# Altium schematic sheet WalkMod B0.SchDoc
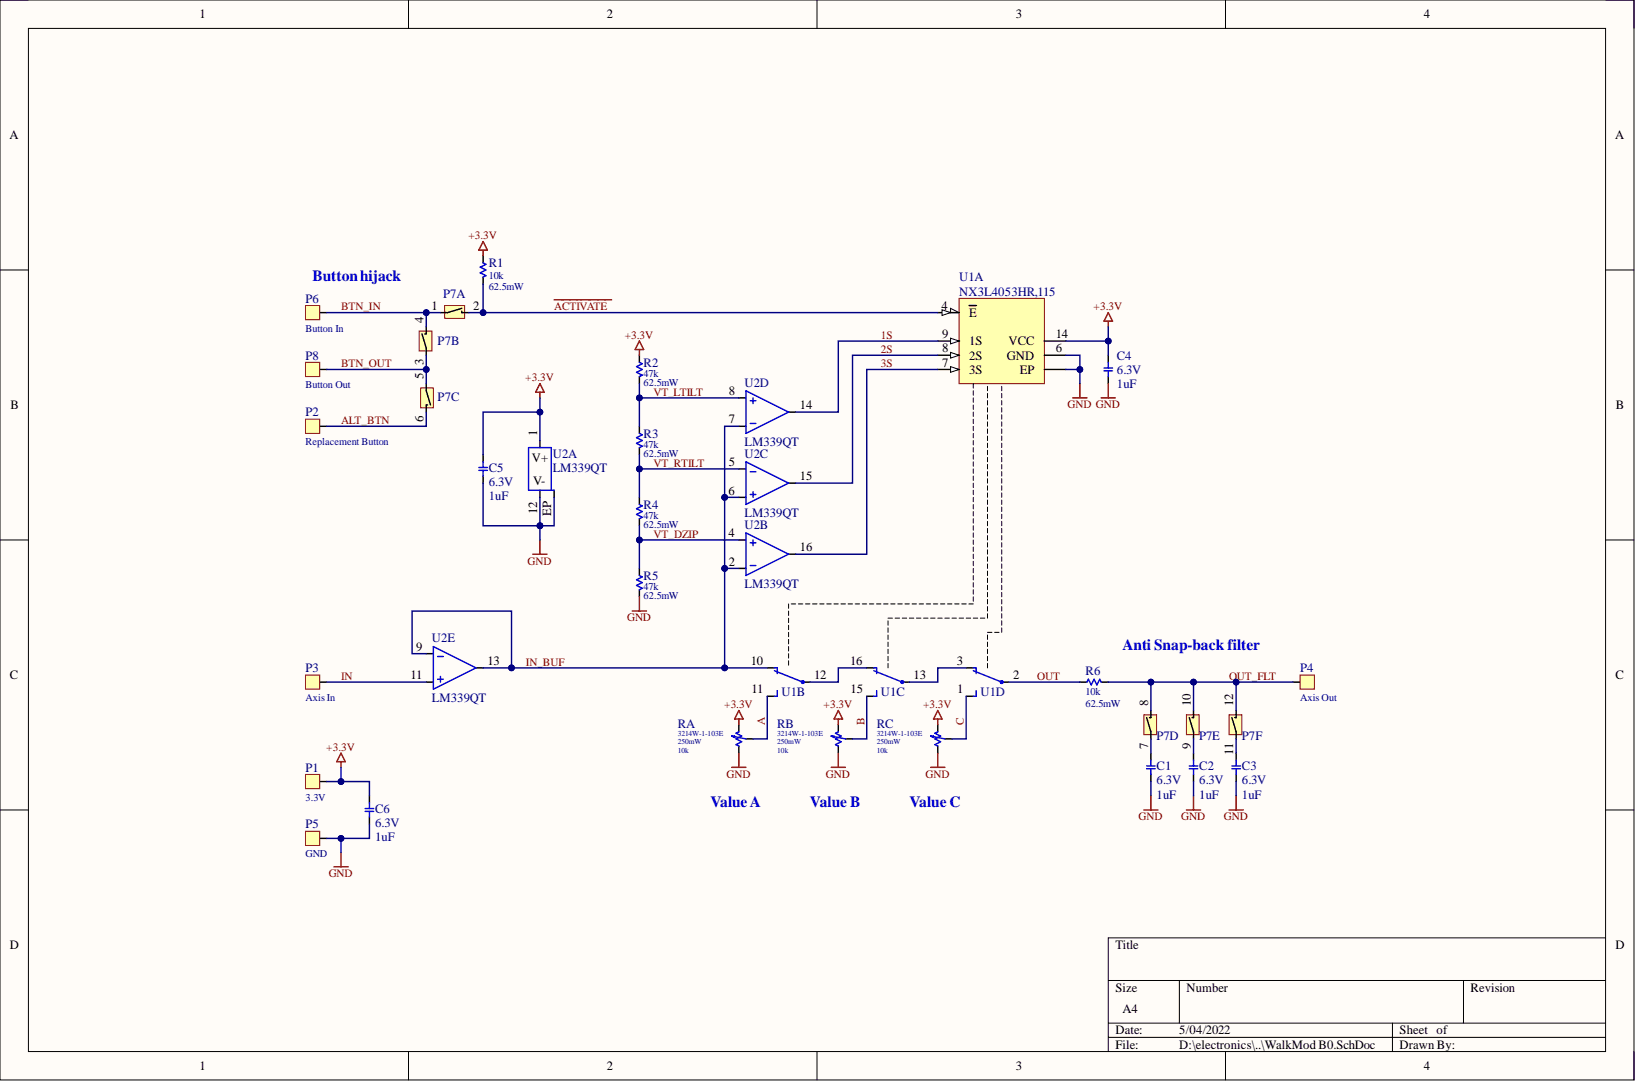
[diagram p0: WalkMod B0.SchDoc]

## Parts
C1  value 1uF  Value2: 6.3V  [CX7R0603K]  (hidden comment: 06036C105JAT2A)
C2  value 1uF  Value2: 6.3V  [CX7R0603K]  (hidden comment: 06036C105JAT2A)
C3  value 1uF  Value2: 6.3V  [CX7R0603K]  (hidden comment: 06036C105JAT2A)
C4  value 1uF  Value2: 6.3V  [CX7R0603K]  (hidden comment: 06036C105JAT2A)
C5  value 1uF  Value2: 6.3V  [CX7R0603K]  (hidden comment: 06036C105JAT2A)
C6  value 1uF  Value2: 6.3V  [CX7R0603K]  (hidden comment: 06036C105JAT2A)
P1  Name: 3.3V  [PAD_2X1.5_SQUARE]  (hidden comment: SMD Pad 1 pin)
P2  SMD Pad 1 pin  [PAD_2X1.5_SQUARE]  (value not drawn)
P3  Name: Axis In  [PAD_2X1.5_SQUARE]  (hidden comment: SMD Pad 1 pin)
P4  Name: Axis Out  [PAD_2X1.5_SQUARE]  (hidden comment: SMD Pad 1 pin)
P5  Name: GND  [PAD_2X1.5_SQUARE]  (hidden comment: SMD Pad 1 pin)
P6  Name: Button In  [PAD_2X1.5_SQUARE]  (hidden comment: SMD Pad 1 pin)
P7  DS05-127-2-06BK-SMT-TR  [CUI-DS05-127-2-06BK-SMT_C]  (value not drawn)  (parts A,B,C,D,E,F)
P8  Name: Button Out  [PAD_2X1.5_SQUARE]  (hidden comment: SMD Pad 1 pin)
R1  value 10k  Value2: 62.5mW  [AT0402_C]  (hidden comment: AT0402FRE0710KL)
R2  value 47k  Value2: 62.5mW  [RT0402_C]  (hidden comment: RT0402DRD0747KL)
R3  value 47k  Value2: 62.5mW  [RT0402_C]  (hidden comment: RT0402DRD0747KL)
R4  value 47k  Value2: 62.5mW  [RT0402_C]  (hidden comment: RT0402DRD0747KL)
R5  value 47k  Value2: 62.5mW  [RT0402_C]  (hidden comment: RT0402DRD0747KL)
R6  value 10k  Value2: 62.5mW  [AT0402_C]  (hidden comment: AT0402FRE0710KL)
RA  3214W-1-103E  value 10k  Value2: 250mW  [BOURNS_3214W_C]
RB  3214W-1-103E  value 10k  Value2: 250mW  [BOURNS_3214W_C]
RC  3214W-1-103E  value 10k  [BOURNS_3214W_C]
U1  NX3L4053HR,115  [HXQFN16_SOT1039-2_C]  (parts A,B,C,D)
U2  LM339QT  [QFN16_0.5_C]  (parts A,B,C,D,E)

## Nets
+3.3V: C4.2 C5.2 C6.2 P1.1 R1.1 R2.2 RA.3 RB.3 RC.3 U1.14(VCC) U2.1(V+)
GND: C1.1 C2.1 C3.1 C4.1 C5.1 C6.1 P5.1 R5.1 RA.1 RB.1 RC.1 U1.6(GND) U1.EP U2.12(V-) U2.EP
1S: U1.9(1S) U2.14
2S: U1.8(2S) U2.15
3S: U1.7(3S) U2.16
A: RA.2 U1.11
~{ACTIVATE}: P7.2 R1.2 U1.4(E)
ALT_BTN: P2.1 P7.6
B: RB.2 U1.15
BTN_IN: P6.1 P7.1 P7.4
BTN_OUT: P7.3 P7.5 P8.1
C: RC.2 U1.1
IN: P3.1 U2.11
IN_BUF: U1.10 U2.2 U2.6 U2.7 U2.9 U2.13
OUT: R6.1 U1.2
OUT_FLT: P4.1 P7.8 P7.10 P7.12 R6.2
VT_DZIP: R4.1 R5.2 U2.4
VT_LTILT: R2.1 R3.2 U2.8
VT_RTILT: R3.1 R4.2 U2.5
NetC1_2: C1.2 P7.7
NetC2_2: C2.2 P7.9
NetC3_2: C3.2 P7.11
NetU1_3: U1.3 U1.13
NetU1_12: U1.12 U1.16

## Library data of the parts
C1-C6 = kyocera-C-X7R-0603: SMD Capacitor; CapacitanceCode: 105; Dielectric: C; MaxThicknessCode: K; ToleranceCode: J; VoltageCode: 6; Component Kind: Standard; Size: 0603; Library Name: WalkMod.IntLib
P1 P3-P6 P8 = SMD Pad 1 pin: Single SMD Pad; Component Kind: Standard; Library Name: WalkMod.IntLib
P2 = SMD Pad 1 pin: Single SMD Pad; Name: Replacement Button; Component Kind: Standard; Library Name: WalkMod.IntLib
P7 = DS05-127-2-06BK-SMT-TR: Dip Switch SPST 6 Position Surface Mount Slide (Standard) Actuator 25mA 24VDC; Component Kind: Standard; Type: DS05-127-2-06BK-SMT-TR; Library Name: WalkMod.IntLib
R1 R6 = AT0402FRE0710KL: 10 kOhms ±1% 0.063W, 1/16W Chip Resistor 0402 (1005 Metric) Anti-Sulfur, Automotive AEC-Q200, Moisture Resistant Thin Film; Component Kind: Standard; Type: AT0402FRE0710KL; Library Name: WalkMod.IntLib
R2-R5 = RT0402DRD0747KL: 47 kOhms ±0.5% 0.063W, 1/16W Chip Resistor 0402 (1005 Metric) - Thin Film; Component Kind: Standard; Type: RT0402DRD0747KL; Library Name: WalkMod.IntLib
RA RB = 3214W-1-103E: 10 kOhms 0.25W, 1/4W J Lead Surface Mount Trimmer Potentiometer Cermet 5.0 Turn Top Adjustment; Component Kind: Standard; Type: 3214W-1-103E; Library Name: WalkMod.IntLib
RC = 3214W-1-103E: 10 kOhms 0.25W, 1/4W J Lead Surface Mount Trimmer Potentiometer Cermet 5.0 Turn Top Adjustment; Value2: 250mW; Component Kind: Standard; Type: 3214W-1-103E; Library Name: WalkMod.IntLib
U1 = NX3L4053: 3 Circuit IC Switch 2:1 800mOhm 16-HXQFN (3x3); Manufacturer Part Number 1: NXP USA Inc.; Component Kind: Standard; Manufacturer 1: NX3L4053HR,115; Type: NX3L4053HR,115; Library Name: WalkMod.IntLib
U2 = LM339QT: Comparator General Purpose CMOS, DTL, ECL, MOS, Open-Collector, TTL 16-QFN (3x3); Manufacturer 1: STMicroelectronics; Component Kind: Standard; Manufacturer Part Number 1: LM339QT; Type: LM339QT; Library Name: WalkMod.IntLib
